# Altium schematic sheet Sheet1.SchDoc
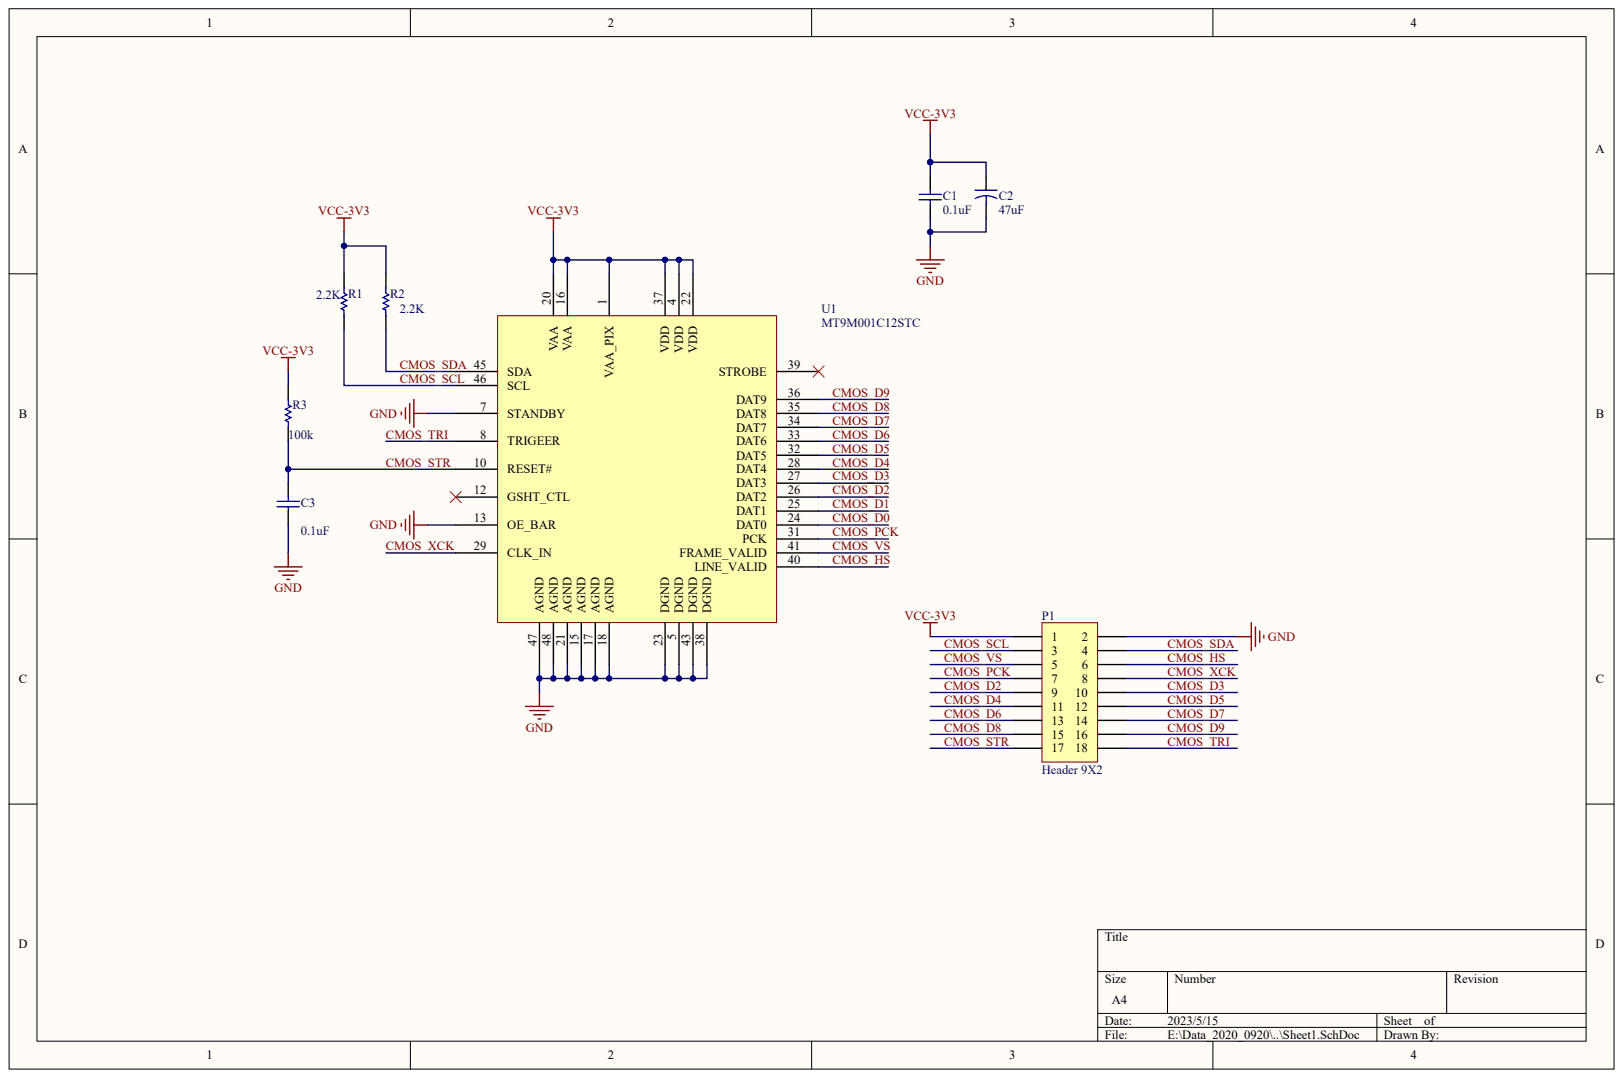
[diagram p0: Sheet1.SchDoc]

## Parts
C1  0.1uF
C2  47uF
C3  0.1uf
P1  Header 9X2
R1  2.2K
R2  2.2K
R3  100k
U1  MT9M001C12STC

## Nets
GND: C1.1 C2.2 C3.1 P1.2 U1.5(DGND) U1.7(STANDBY) U1.13(OE_BAR) U1.15(AGND) U1.17(AGND) U1.18(AGND) U1.21(AGND) U1.23(DGND) U1.38(DGND) U1.43(DGND) U1.47(AGND) U1.48(AGND)
VCC-3V3: C1.2 C2.1 P1.1 R1.2 R2.2 R3.2 U1.1(VAA_PIX) U1.4(VDD) U1.16(VAA) U1.20(VAA) U1.22(VDD) U1.37(VDD)
CMOS_D0: U1.24(DAT0)
CMOS_D1: U1.25(DAT1)
CMOS_D2: P1.9 U1.26(DAT2)
CMOS_D3: P1.10 U1.27(DAT3)
CMOS_D4: P1.11 U1.28(DAT4)
CMOS_D5: P1.12 U1.32(DAT5)
CMOS_D6: P1.13 U1.33(DAT6)
CMOS_D7: P1.14 U1.34(DAT7)
CMOS_D8: P1.15 U1.35(DAT8)
CMOS_D9: P1.16 U1.36(DAT9)
CMOS_HS: P1.6 U1.40(LINE_VALID)
CMOS_PCK: P1.7 U1.31(PCK)
CMOS_SCL: P1.3 R1.1 U1.46(SCL)
CMOS_SDA: P1.4 R2.1 U1.45(SDA)
CMOS_STR: C3.2 P1.17 R3.1 U1.10(RESET#)
CMOS_TRI: P1.18 U1.8(TRIGEER)
CMOS_VS: P1.5 U1.41(FRAME_VALID)
CMOS_XCK: P1.8 U1.29(CLK_IN)

Not connected: U1 12(GSHT_CTL) 39(STROBE)

## Library data of the parts
C1-C3 P1 R1-R3 U1 = (no symbol name)
  C1: Published: 8-Jun-2000; LatestRevisionDate: 17-Jul-2002; LatestRevisionNote: Re-released for DXP Platform.; PackageReference: RAD-0.3; PackageDescription: Radial Cap, Thru-Hole; 2 Leads; 0.3 in Pin Spacing; Value: 0.1uf
  C2: Published: 23-Sep-2002; PackageReference: CAPR5-4X5; PackageDescription: Capacitor; 2 Leads; Value: 47uF
  C3: Published: 8-Jun-2000; LatestRevisionDate: 17-Jul-2002; LatestRevisionNote: Re-released for DXP Platform.; PackageReference: RAD-0.3; PackageDescription: Radial Cap, Thru-Hole; 2 Leads; 0.3 in Pin Spacing; Value: 0.1uF
  P1: LatestRevisionDate: 17-Jul-2002; LatestRevisionNote: Re-released for DXP Platform.
  R1 R2: Published: 8-Jun-2000; LatestRevisionDate: 17-Jul-2002; LatestRevisionNote: Re-released for DXP Platform.; PackageReference: AXIAL-0.3; PackageDescription: Axial Device, Thru-Hole; 2 Leads; 0.3 in Pin Spacing; Value: 2.2K
  R3: Published: 8-Jun-2000; LatestRevisionDate: 17-Jul-2002; LatestRevisionNote: Re-released for DXP Platform.; PackageReference: AXIAL-0.3; PackageDescription: Axial Device, Thru-Hole; 2 Leads; 0.3 in Pin Spacing; Value: 100K
  C1-C3 P1 R1-R3: Publisher: Altium Limited
